# Altium schematic sheet COMMUNICATIONS.SchDoc
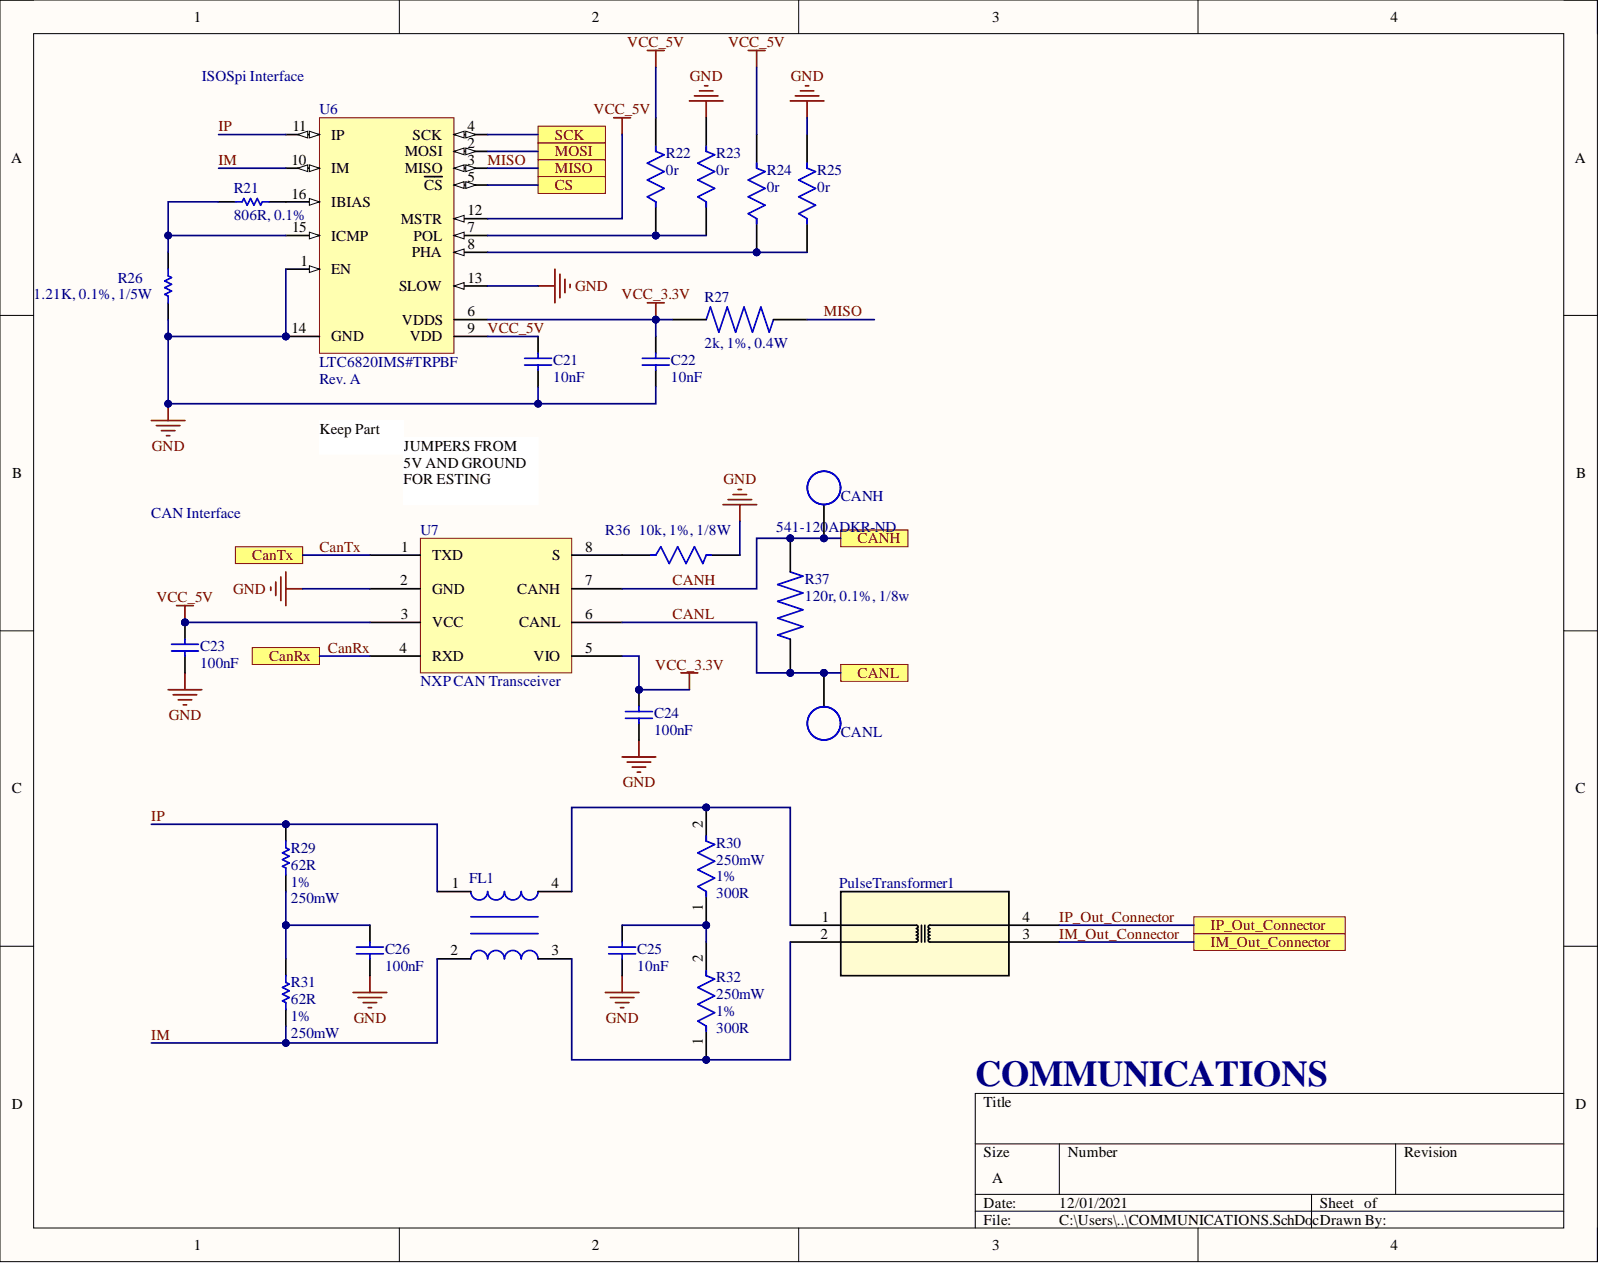
[diagram p0: COMMUNICATIONS.SchDoc]

## Parts
C21  10nF  [CAPC2013X70X45LL15T25]
C22  10nF  [CAPC2013X70X45LL15T25]
C23  100nF  [CAPC2013X145X45NL30T25]
C24  100nF  [CAPC2013X145X45NL30T25]
C25  10nF  [CAPC2013X70X45LL15T25]
C26  100nF  [CAPC2013X145X45NL30T25]
CANH  5015  [5015]  (value not drawn)
CANL  5015  [5015]  (value not drawn)
FL1  ACT45B-220-2P-TL003  [FIL_ACT45B-220-2P-TL003]  (value not drawn)
PulseTransformer1  PE-68386NLT  [PE-68386NL_PUL]  (value not drawn)
R21  806R, 0.1%  Tolerance: 0.1%  [RESC2013X50X30NL20T20]
R22  0r  [FP-CRCW0805-HPe3-IPC_A]
R23  0r  [FP-CRCW0805-HPe3-IPC_A]
R24  0r  [FP-CRCW0805-HPe3-IPC_A]
R25  0r  [FP-CRCW0805-HPe3-IPC_A]
R26  1.21K, 0.1%, 1/5W  [RESC2013X50X30NL20T20]
R27  2k, 1%, 0.4W  [RESC2012X50N]
R29  Max Power Dissipation: 250mW  Resistance: 62R  Resistance Tolerance: 1%  [RESC3216X70X50NL05T20]  (hidden comment: ERJ-8ENF62R0V)
R30  Max Power Dissipation: 250mW  Resistance: 300R  Tolerance: 1%  [FP-CRCW1206-e3-IPC_A]  (hidden comment: CRCW1206300RFKEA)
R31  Max Power Dissipation: 250mW  Resistance: 62R  Resistance Tolerance: 1%  [RESC3216X70X50NL05T20]  (hidden comment: ERJ-8ENF62R0V)
R32  Max Power Dissipation: 250mW  Resistance: 300R  Tolerance: 1%  [FP-CRCW1206-e3-IPC_A]  (hidden comment: CRCW1206300RFKEA)
R36  10k, 1%, 1/8W  [FP-CRCW0805-e3-IPC_A]
R37  120r, 0.1%, 1/8w  Supplier Part Number 1: 541-120ADKR-ND  [RESC2012X55N]
U6  LTC6820IMS#TRPBF  DatasheetVersion: Rev. A  [TSSOP50P490X110-16N]
U7  NXP CAN Transceiver  [NXP CAN Tranceiver]

## Ports
CANH, CANL, CanRx, CanTx, CS, IM_Out_Connector, IP_Out_Connector, MISO, MOSI, SCK

## Nets
GND: C21.1 C22.1 C23.1 C24.1 C25.1 C26.1 R23.2 R25.2 R26.1 R36.2 U6.1(EN) U6.13(SLOW) U6.14(GND) U7.2(GND)
VCC_3.3V: C22.2 C24.2 R27.2 U6.6(VDDS) U7.5(VIO)
CANH: CANH.1 R37.2 U7.7(CANH) ; port CANH
CANL: CANL.1 R37.1 U7.6(CANL) ; port CANL
CanRx: U7.4(RXD) ; port CanRx
CanTx: U7.1(TXD) ; port CanTx
CS: U6.5(CS) ; port CS
IM: FL1.2 R31.1 U6.10(IM)
IM_Out_Connector: PulseTransformer1.3 ; port IM_Out_Connector
IP: FL1.1 R29.2 U6.11(IP)
IP_Out_Connector: PulseTransformer1.4 ; port IP_Out_Connector
MISO: R27.1 U6.3(MISO) ; port MISO
MOSI: U6.2(MOSI) ; port MOSI
SCK: U6.4(SCK) ; port SCK
VCC_5V: C21.2 C23.2 R22.2 R24.2 U6.9(VDD) U6.12(MSTR) U7.3(VCC)
NetC25_2: C25.2 R30.1 R32.2
NetC26_2: C26.2 R29.1 R31.2
NetFL1_3: FL1.3 PulseTransformer1.2 R32.1
NetFL1_4: FL1.4 PulseTransformer1.1 R30.2
NetR21_1: R21.1 R26.2 U6.15(ICMP)
NetR21_2: R21.2 U6.16(IBIAS)
NetR22_1: R22.1 R23.1 U6.7(POL)
NetR24_1: R24.1 R25.1 U6.8(PHA)
NetR36_1: R36.1 U7.8(S)

## Library data of the parts
C21 C22 C25 = CMP-2007-00806-1: Manufacturer: Murata; Manufacturer Part Number 1: GCM216R72A103KA37D; Dielectric: X7R; Tolerance: 10%; Voltage: 100V; Capacitance: 10nF; Case Code (Imperial): 0805; Case Code (Metric): 2012; Case/Package: 0805; Category: Ceramic Capacitors; Component Kind: Standard; ComponentLink1Description: https://datasheet.ciiva.com/11620/product-11620072.pdf?src-supplier=Avnet; ComponentLink1URL: https://datasheet.ciiva.com/11620/product-11620072.pdf?src-supplier=Avnet; ComponentLink2Description: https://datasheet.ciiva.com/18183/getdatasheetpartid-223896-18183680.pdf?src-supplier=Verical; ComponentLink2URL: https://datasheet.ciiva.com/18183/getdatasheetpartid-223896-18183680.pdf?src-supplier=Verical; ComponentLink3Description: https://datasheet.ciiva.com/6270/s0717e-6270558.pdf?src-supplier=Digi-Key; ComponentLink3URL: https://datasheet.ciiva.com/6270/s0717e-6270558.pdf?src-supplier=Digi-Key; ComponentLink4Description: https://datasheet.ciiva.com/16214/s0717e-16214647.pdf?src-supplier=Future+Electronics; ComponentLink4URL: https://datasheet.ciiva.com/16214/s0717e-16214647.pdf?src-supplier=Future+Electronics; Construction: Flat; Depth: 1.25mm; Height: 0.6mm; Lead Free: Lead Free; Length: 2mm; Library Name: Altium Content Vault; Manufacturer 1: Murata; Max Operating Temperature: 125°C; Min Operating Temperature: -55°C; Mount: Surface Mount; Package Quantity: 1; Packaging: Tape and Reel; Part Number: GCM216R72A103KA37D; Pricing 1: 1=0.36000, 10=0.24900, 50=0.16640, 100=0.14140, 500=0.09980, 1000=0.08732 (USD); Radiation Hardening: No; Ratings: AEC-Q200; RoHS: Yes; RoHS Compliant: Yes; Series: GCM; Stock 1: 2316; Supplier 1: Digi-Key; Supplier Part Number 1: 490-6060-1-ND; Termination: SMD/SMT; Thickness: 0.028inch; Voltage Rating: 100V; Voltage Rating (DC): 100V; Width: 0.049inch
C23 C24 C26 = CMP-2007-03212-1: Manufacturer: Murata; Manufacturer Part Number 1: GCJ21BR71H104KA01L; Dielectric: X7R; Tolerance: 10%; Voltage Rating: 50V; Capacitance: 100nF; Case Code (Imperial): 0805; Case Code (Metric): 2012; Case/Package: 0805; Category: Ceramic Capacitors; Component Kind: Standard; ComponentLink1Description: https://datasheet.ciiva.com/17453/product-17453782.pdf?src-supplier=Avnet+Europe; ComponentLink1URL: https://datasheet.ciiva.com/17453/product-17453782.pdf?src-supplier=Avnet+Europe; ComponentLink2Description: https://datasheet.ciiva.com/18183/getdatasheetpartid-663717-18183469.pdf?src-supplier=Verical; ComponentLink2URL: https://datasheet.ciiva.com/18183/getdatasheetpartid-663717-18183469.pdf?src-supplier=Verical; ComponentLink3Description: https://datasheet.ciiva.com/136/s0717e-136749.pdf?src-supplier=Digi-Key; ComponentLink3URL: https://datasheet.ciiva.com/136/s0717e-136749.pdf?src-supplier=Digi-Key; ComponentLink4Description: https://datasheet.ciiva.com/136/s0717e-136750.pdf?src-supplier=Digi-Key; ComponentLink4URL: https://datasheet.ciiva.com/136/s0717e-136750.pdf?src-supplier=Digi-Key; ComponentLink5Description: https://datasheet.ciiva.com/136/s0717e-136751.pdf?src-supplier=Digi-Key; ComponentLink5URL: https://datasheet.ciiva.com/136/s0717e-136751.pdf?src-supplier=Digi-Key; Construction: Flat; Depth: 1.25mm; Features: Soft Termination; Height: 1.25mm; Lead Free: Lead Free; Length: 2mm; Library Name: Altium Content Vault; Manufacturer 1: Murata; Max Operating Temperature: 125°C; Min Operating Temperature: -55°C; Mount: Surface Mount; Package Quantity: 3000; Packaging: Tape and Reel; Part Number: GCJ21BR71H104KA01L; Pricing 1: 1=0.48000, 10=0.34200, 50=0.25880, 100=0.22540, 500=0.16698, 1000=0.14193 (USD); Radiation Hardening: No; Ratings: AEC-Q200; RoHS: Yes; RoHS Compliant: Yes; Series: GCJ; Stock 1: 105376; Supplier 1: Digi-Key; Supplier Part Number 1: 490-5803-1-ND; Termination: SMD/SMT; Thickness: 0.057inch; Voltage Rating (DC): 50V; Width: 0.049inch
CANH CANL = 5015: SMD Test Point; Manufacturer: Keystone Electronics; TargetLibrary: RITRacing-Connectors; Component Kind: Standard; ComponentLink1Description: Datasheet; ComponentLink1URL: http://www.mouser.com/ds/2/215/005-5009-743680.pdf; Library Name: Connectors.IntLib; PartNumber: 5015; Published: 22Oct2016; Status: Active; Publisher: T.Tallo
FL1 = ACT45B-220-2P-TL003: 100ÂµH @ 100kHz 2 Line Common Mode Choke Surface Mount 5.8 kOhms @ 10MHz 150mA DCR 2Ohm; Availability: Unavailable; Component Kind: Standard; MP: ACT45B-220-2P-TL003; Package: None; Price: None; Supplier 1: Digi-Key; Supplier 2: Digi-Key; Supplier 3: Digi-Key; Supplier 4: Mouser; Supplier Part Number 1: 445-3957-1-ND; Supplier Part Number 2: 445-3957-6-ND; Supplier Part Number 3: 445-3957-2-ND; Supplier Part Number 4: 810-ACT45B2202PTL003; Library Name: BMS_MasterboardUpdated_1.SCHLIB
PulseTransformer1 = PE-68386NLT: No Description Available; Built_By: EMA_Cory; SourceLibrary: Pulse_Electronics_2019-12-18; Vendor: Pulse Electronics Corporation; Component Kind: Standard; Manufacturer_Part_Number: PE-68386NLT; RefDes: RefDes; Supplier 1: Digi-Key; Supplier 2: Digi-Key; Supplier 3: Digi-Key; Supplier 4: Mouser; Supplier Part Number 1: 553-1776-1-ND; Supplier Part Number 2: 553-1776-6-ND; Supplier Part Number 3: 553-1776-2-ND; Supplier Part Number 4: 673-PE-68386NLT; Type: DEV; Copyright: Copyright (C) 2018 Accelerated Designs. All rights reserved; Library Name: BMS_MasterboardUpdated.SCHLIB
R21 = CMP-2001-04126-1: Manufacturer: Vishay Dale; Manufacturer Part Number 1: TNPW0805806RBEEN; Part Number: CRCW0805806RFKEA; Max Power Dissipation: 125mW; Temperature Coefficient: 25ppm/°C; Voltage Rating: 150V; Voltage Rating (DC): 100V; Case Code (Imperial): 0805; Case Code (Metric): 2012; Case/Package: 0805; Category: Chip SMD Resistors; Component Kind: Standard; ComponentLink1Description: https://datasheet.ciiva.com/2612/tnpw-e3-2612881.pdf?src-supplier=Digi-Key; ComponentLink1URL: https://datasheet.ciiva.com/2612/tnpw-e3-2612881.pdf?src-supplier=Digi-Key; ComponentLink2Description: https://datasheet.ciiva.com/8919/tnpw-e3-8919757.pdf?src-supplier=Avnet; ComponentLink2URL: https://datasheet.ciiva.com/8919/tnpw-e3-8919757.pdf?src-supplier=Avnet; ComponentLink3Description: https://datasheet.ciiva.com/48524/tnpw-e3-48524410.pdf?src-supplier=Avnet; ComponentLink3URL: https://datasheet.ciiva.com/48524/tnpw-e3-48524410.pdf?src-supplier=Avnet; Contact Plating: Tin; Features: Moisture Resistant,Automotive AEC-Q200,Anti-Sulfur; Height: 0.022inch; Length: 0.079inch; Library Name: Altium Content Vault; Manufacturer 1: Vishay Dale; Manufacturer 2: Vishay Dale; Manufacturer Part Number 2: TNPW0805806RBEEN; Max Operating Temperature: 125°C; Min Operating Temperature: -55°C; Number of Terminations: 2; Package Quantity: 1000; Packaging: Tape and Reel; Power Rating: 125mW; Pricing 1: 1000=0.31280 (USD); Pricing 2: 1=0.92000, 10=0.82300, 50=0.82300, 100=0.62200, 500=0.52900, 1000=0.29900, 10000=0.26400 (USD); Radiation Hardening: No; Resistance: 806R; Resistor Type: Thin Film; RoHS: Yes; RoHS Compliant: Yes; Series: TNPW; Stock 2: 696; Supplier 1: Digi-Key; Supplier 2: Mouser; Supplier Part Number 1: 541-TNPW0805806RBEENTR-ND; Supplier Part Number 2: 71-TNPW0805806RBEEN; Termination: SMD/SMT; Width: 0.049inch
R22-R25 = CMP-2001-04185-2: RES Thick Film, 0Ω, 0.5W, 0805; Manufacturer 1: Vishay Dale; Manufacturer Part Number 1: CRCW08050000Z0EAHP; Max Power Dissipation: 500mW; Power Rating: 330mW; Resistance: 0mR; Temperature Coefficient: 0ppm/°C; Tolerance: 5%; Voltage Rating: 150V; Case Code (Imperial): 0805; Case Code (Metric): 2012; Case/Package: 0805; Category: Chip SMD Resistors; Component Kind: Standard; ComponentLink1Description: https://datasheet.ciiva.com/2474/crcwhpe3-2474632.pdf?src-supplier=Digi-Key; ComponentLink1URL: https://datasheet.ciiva.com/2474/crcwhpe3-2474632.pdf?src-supplier=Digi-Key; ComponentLink2Description: https://datasheet.ciiva.com/2474/crcwhpe3-2474633.pdf?src-supplier=Digi-Key; ComponentLink2URL: https://datasheet.ciiva.com/2474/crcwhpe3-2474633.pdf?src-supplier=Digi-Key; ComponentLink3Description: https://datasheet.ciiva.com/2474/crcwhpe3-2474634.pdf?src-supplier=Digi-Key; ComponentLink3URL: https://datasheet.ciiva.com/2474/crcwhpe3-2474634.pdf?src-supplier=Digi-Key; ComponentLink4Description: https://datasheet.ciiva.com/9109/crcwhpe3-9109934.pdf?src-supplier=Avnet; ComponentLink4URL: https://datasheet.ciiva.com/9109/crcwhpe3-9109934.pdf?src-supplier=Avnet; ComponentLink5Description: https://datasheet.ciiva.com/5549/crcwhpe3-46056-5549241.pdf?src-supplier=Mouser; ComponentLink5URL: https://datasheet.ciiva.com/5549/crcwhpe3-46056-5549241.pdf?src-supplier=Mouser; ComponentLink6Description: https://datasheet.ciiva.com/16883/crcwhpe3-16883643.pdf?src-supplier=Avnet+Europe; ComponentLink6URL: https://datasheet.ciiva.com/16883/crcwhpe3-16883643.pdf?src-supplier=Avnet+Europe; ComponentLink7Description: https://datasheet.ciiva.com/16498/crcwhpe3-16498294.pdf?src-supplier=Future+Electronics; ComponentLink7URL: https://datasheet.ciiva.com/16498/crcwhpe3-16498294.pdf?src-supplier=Future+Electronics; ComponentLink8Description: https://datasheet.ciiva.com/28621/1750852-28621406.pdf?src-supplier=Element14; ComponentLink8URL: https://datasheet.ciiva.com/28621/1750852-28621406.pdf?src-supplier=Element14; ComponentLink9Description: https://datasheet.ciiva.com/7859/crcwhpe3-7859727.pdf?src-supplier=Element14; ComponentLink9URL: https://datasheet.ciiva.com/7859/crcwhpe3-7859727.pdf?src-supplier=Element14; Composition: Thick Film; Construction: Rectangular; Contact Plating: Tin; Depth: 1.25mm; Features: Automotive AEC-Q200; Height: 0.024inch; Lead Free: Lead Free; Length: 2mm; Library Name: Altium Content Vault; Max Operating Temperature: 155°C; Military Standard: Not; Min Operating Temperature: -55°C; Mount: Surface Mount; Number of Pins: 2; Number of Terminations: 2; Package Quantity: 5000; Packaging: Tape and Reel; Pricing 1: 1=0.21000, 10=0.17600, 25=0.12800, 50=0.09280, 100=0.06880, 250=0.05280, 500=0.04160, 1000=0.02880 (USD); Radiation Hardening: No; REACH SVHC: No SVHC; Resistor Type: Thick Film; RoHS: Yes; RoHS Compliant: Yes; Series: CRCW-HP; Size Code: 0805; Stock 1: 60898; Supplier 1: Digi-Key; Supplier Part Number 1: 541-0.0TBCT-ND; Technology: THICK FILM; Termination: Solder; Voltage Rating (DC): 150V; Weight: 0.000194oz; Width: 0.049inch
R26 = CMP-2001-04126-1: Manufacturer: Vishay Dale; Manufacturer Part Number 1: TNPW0805806RBEEN; Part Number: CRCW0805806RFKEA; Max Power Dissipation: 125mW; Resistance: 806R; Temperature Coefficient: 25ppm/°C; Voltage Rating: 150V; Voltage Rating (DC): 100V; Case Code (Imperial): 0805; Case Code (Metric): 2012; Case/Package: 0805; Category: Chip SMD Resistors; Component Kind: Standard; ComponentLink1Description: https://datasheet.ciiva.com/2612/tnpw-e3-2612881.pdf?src-supplier=Digi-Key; ComponentLink1URL: https://datasheet.ciiva.com/2612/tnpw-e3-2612881.pdf?src-supplier=Digi-Key; ComponentLink2Description: https://datasheet.ciiva.com/8919/tnpw-e3-8919757.pdf?src-supplier=Avnet; ComponentLink2URL: https://datasheet.ciiva.com/8919/tnpw-e3-8919757.pdf?src-supplier=Avnet; ComponentLink3Description: https://datasheet.ciiva.com/48524/tnpw-e3-48524410.pdf?src-supplier=Avnet; ComponentLink3URL: https://datasheet.ciiva.com/48524/tnpw-e3-48524410.pdf?src-supplier=Avnet; ComponentLink4Description: https://datasheet.ciiva.com/9105/tnpw-e3-9105739.pdf?src-supplier=Avnet; ComponentLink4URL: https://datasheet.ciiva.com/9105/tnpw-e3-9105739.pdf?src-supplier=Avnet; ComponentLink5Description: https://datasheet.ciiva.com/2608/tnpw-e3-2608321.pdf?src-supplier=Digi-Key; ComponentLink5URL: https://datasheet.ciiva.com/2608/tnpw-e3-2608321.pdf?src-supplier=Digi-Key; ComponentLink6Description: https://datasheet.ciiva.com/41828/tnpw-e3-41828137.pdf?src-supplier=Digi-Key; ComponentLink6URL: https://datasheet.ciiva.com/41828/tnpw-e3-41828137.pdf?src-supplier=Digi-Key; Contact Plating: Tin; Features: Moisture Resistant,Automotive AEC-Q200,Anti-Sulfur; Height: 0.022inch; Length: 0.079inch; Library Name: Altium Content Vault; Manufacturer 1: Vishay Dale; Manufacturer 2: Vishay Dale; Manufacturer 3: Vishay Dale; Manufacturer Part Number 2: TNPW0805806RBEEN; Manufacturer Part Number 3: TNPW08051K21BEEA; Max Operating Temperature: 125°C; Min Operating Temperature: -55°C; Number of Terminations: 2; Package Quantity: 1000; Packaging: Tape and Reel; Power Rating: 125mW; Pricing 1: 1000=0.31280 (USD); Pricing 2: 1=0.92000, 10=0.82300, 50=0.82300, 100=0.62200, 500=0.52900, 1000=0.29900, 10000=0.26400 (USD); Pricing 3: 1=0.74000, 10=0.54800, 100=0.31930, 1000=0.17905, 2500=0.17544 (USD); Radiation Hardening: No; REACH SVHC: Unknown; Resistance Tolerance: 0.1%; Resistor Type: Thin Film; RoHS: Yes; RoHS Compliant: Yes; Series: TNPW; Stock 2: 696; Stock 3: 4750; Supplier 1: Digi-Key; Supplier 2: Mouser; Supplier 3: Digi-Key; Supplier Part Number 1: 541-TNPW0805806RBEENTR-ND; Supplier Part Number 2: 71-TNPW0805806RBEEN; Supplier Part Number 3: 541-2057-1-ND; Termination: SMD/SMT; Tolerance: 0.1%; Width: 0.049inch
R27 = RCS08052K00FKEA: Res Thick Film 0805 2K Ohm 1% 0.4W ±100ppm/C Pad SMD Automotive T/R; Manufacturer 1: Vishay Dale; Max Power Dissipation: 400mW; Resistance: 2kR; Temperature Coefficient: 100ppm/°C; Availability: Unavailable; Case Code (Imperial): 0805; Case Code (Metric): 2012; Case/Package: 0805; Category: Chip SMD Resistors; Component Kind: Standard; ComponentLink1Description: http://www.vishay.com/docs/20065/rcse3.pdf?src-supplier=Digi-Key; ComponentLink1URL: http://www.vishay.com/docs/20065/rcse3.pdf?src-supplier=Digi-Key; Features: Automotive AEC-Q200; Height: 0.02inch; Length: 0.079inch; Manufacturer Part Number 1: RCS08052K00FKEA; Max Operating Temperature: 155°C; Min Operating Temperature: -55°C; MP: RCS08052K00FKEA; Number of Terminations: 2; Package: None; Packaging: Tape and Reel; Price: None; Pricing 1: 1=0.26000, 10=0.21800, 100=0.08510, 1000=0.03560, 2500=0.03264 (USD); Resistor Type: Thick Film; RoHS: Yes; Stock 1: 4243; Supplier 1: Digi-Key; Supplier Part Number 1: 541-2840-1-ND; Tolerance: 1%; Width: 0.049inch; Library Name: BMS_MasterboardUpdated_1.SCHLIB
R29 R31 = CMP-2003-00451-1: Manufacturer: Panasonic; Temperature Coefficient: 100ppm/°C; Voltage Rating: 200V; Case Code (Imperial): 1206; Case Code (Metric): 3216; Case/Package: 1206; Category: Chip SMD Resistors; Component Kind: Standard; ComponentLink1Description: https://datasheet.ciiva.com/9188/aoa0000ce2-9188406.pdf?src-supplier=Avnet; ComponentLink1URL: https://datasheet.ciiva.com/9188/aoa0000ce2-9188406.pdf?src-supplier=Avnet; ComponentLink2Description: https://datasheet.ciiva.com/22762/1678782-22762886.pdf?src-supplier=Farnell; ComponentLink2URL: https://datasheet.ciiva.com/22762/1678782-22762886.pdf?src-supplier=Farnell; ComponentLink3Description: https://datasheet.ciiva.com/46440/1678782-46440394.pdf?src-supplier=Element14; ComponentLink3URL: https://datasheet.ciiva.com/46440/1678782-46440394.pdf?src-supplier=Element14; Construction: Rectangular; Contact Plating: Tin; Depth: 1.6mm; Features: Automotive AEC-Q200; Height: 0.028inch; Lead Free: Lead Free; Length: 3.2mm; Library Name: Altium Content Vault; Manufacturer 1: Panasonic; Manufacturer 2: Panasonic; Manufacturer 3: Panasonic; Manufacturer 4: Panasonic; Manufacturer Part Number 1: ERJ-8ENF62R0V; Manufacturer Part Number 2: ERJ-8ENF62R0V; Manufacturer Part Number 3: ERJ-8ENF62R0V; Manufacturer Part Number 4: ERJ-8ENF62R0V; Max Operating Temperature: 155°C; Military Standard: Not; Min Operating Temperature: -55°C; Mount: Surface Mount; Number of Terminations: 2; Package Quantity: 1; Packaging: Tape and Reel; Part Number: ERJ-8ENF62R0V; Power Rating: 250mW; Pricing 1: 1=0.10000, 10=0.09300, 100=0.03560, 1000=0.01569, 2500=0.01355 (USD); Pricing 2: 1=0.10000, 10=0.09300, 100=0.03560, 1000=0.01569, 2500=0.01355 (USD); Pricing 3: 1=0.00800, 25=0.00800, 50=0.00800, 100=0.00800, 250=0.00800, 500=0.00800, 1000=0.00800 (USD); Pricing 4: 1=0.10000, 10=0.09300, 50=0.09300, 100=0.03800, 250=0.03700, 500=0.03600, 1000=0.01600, 2500=0.01400, 5000=0.01300, 10000=0.01300 (USD); Radiation Hardening: No; REACH SVHC: Yes; Resistor Type: Thick Film; RoHS: Yes; RoHS Compliant: Yes; Series: ERJ; Stock 1: 91284; Stock 2: 91284; Stock 3: 46632; Stock 4: 31443; Supplier 1: Digi-Key; Supplier 2: Digi-Key; Supplier 3: Newark; Supplier 4: Mouser; Supplier Part Number 1: P62.0FCT-ND; Supplier Part Number 2: P62.0FDKR-ND; Supplier Part Number 3: 90W3970; Supplier Part Number 4: 667-ERJ-8ENF62R0V; Termination: SMD/SMT; Tolerance: 1%; Voltage Rating (DC): 200V; Width: 1.6mm
R30 R32 = CMP-1014-00449-3: RES Thick Film, 300Ω, 1%, 0.25W, 100ppm/°C, 1206; Voltage Rating: 200V; Case Code (Imperial): 1206; Case Code (Metric): 3216; Case/Package: 1206; Component Kind: Standard; Composition: Thick Film; Contact Plating: Tin; Depth: 1.6mm; Features: Automotive AEC-Q200; Height: 0.55mm; Lead Free: Lead Free; Length: 3.2mm; Library Name: Altium Content Vault; Max Operating Temperature: 155°C; Military Standard: Not; Min Operating Temperature: -55°C; Mount: Surface Mount; Number of Terminations: 2; Package Quantity: 10; Packaging: Tape and Reel; Power Rating: 250mW; Radiation Hardening: No; Supplier 1: Newark; Supplier Part Number 1: 27W4914; Temperature Coefficient: 100ppm/°C; Termination: SMD/SMT; Voltage Rating (DC): 200V; Weight: 0.000353oz; Width: 0.063inch; Working Voltage: 200V
R36 = CMP-2001-04908-2: RES Thick Film, 10kΩ, 1%, 0.125W, 100ppm/°C, 0805; Manufacturer 1: Vishay; Manufacturer Part Number 1: CRCW080510K0FKEA; Max Power Dissipation: 125mW; Resistance: 10kR; Voltage Rating: 150V; Case Code (Imperial): 0805; Case Code (Metric): 2012; Case/Package: 0805; Category: Chip SMD Resistors; Component Kind: Standard; ComponentLink1Description: https://datasheet.ciiva.com/11808/0900766b80d98b26-11808216.pdf?src-supplier=RSComponents; ComponentLink1URL: https://datasheet.ciiva.com/11808/0900766b80d98b26-11808216.pdf?src-supplier=RSComponents; ComponentLink2Description: https://datasheet.ciiva.com/8337/dcrcwe3-8337773.pdf?src-supplier=Avnet; ComponentLink2URL: https://datasheet.ciiva.com/8337/dcrcwe3-8337773.pdf?src-supplier=Avnet; ComponentLink3Description: https://datasheet.ciiva.com/8337/dcrcwe3-8337774.pdf?src-supplier=Avnet; ComponentLink3URL: https://datasheet.ciiva.com/8337/dcrcwe3-8337774.pdf?src-supplier=Avnet; ComponentLink4Description: https://datasheet.ciiva.com/5547/dcrcwe3-109170-5547559.pdf?src-supplier=Mouser; ComponentLink4URL: https://datasheet.ciiva.com/5547/dcrcwe3-109170-5547559.pdf?src-supplier=Mouser; ComponentLink5Description: https://datasheet.ciiva.com/46394/1720486-46394381.pdf?src-supplier=Element14; ComponentLink5URL: https://datasheet.ciiva.com/46394/1720486-46394381.pdf?src-supplier=Element14; ComponentLink6Description: https://datasheet.ciiva.com/16199/dcrcwe3-16199086.pdf?src-supplier=Future+Electronics; ComponentLink6URL: https://datasheet.ciiva.com/16199/dcrcwe3-16199086.pdf?src-supplier=Future+Electronics; ComponentLink7Description: https://datasheet.ciiva.com/16883/dcrcwe3-16883708.pdf?src-supplier=Avnet+Europe; ComponentLink7URL: https://datasheet.ciiva.com/16883/dcrcwe3-16883708.pdf?src-supplier=Avnet+Europe; ComponentLink8Description: https://datasheet.ciiva.com/16719/70201119-16719082.pdf?src-supplier=Allied+Electronics; ComponentLink8URL: https://datasheet.ciiva.com/16719/70201119-16719082.pdf?src-supplier=Allied+Electronics; ComponentLink9Description: https://datasheet.ciiva.com/16514/dcrcwe3-16514907.pdf?src-supplier=Avnet+Asia; ComponentLink9URL: https://datasheet.ciiva.com/16514/dcrcwe3-16514907.pdf?src-supplier=Avnet+Asia; ComponentLink10Description: https://datasheet.ciiva.com/16755/70201119-16755389.pdf?src-supplier=Allied+Electronics; ComponentLink10URL: https://datasheet.ciiva.com/16755/70201119-16755389.pdf?src-supplier=Allied+Electronics; Composition: Thick Film; Construction: Rectangular; Contact Plating: Tin; Depth: 1.25mm; Features: Automotive AEC-Q200; Height: 0.45mm; Lead Free: Lead Free; Length: 2mm; Library Name: Altium Content Vault; Manufacturer 2: Vishay; Manufacturer Part Number 2: CRCW080510K0FKEA; Max Operating Temperature: 155°C; Military Standard: Not; Min Operating Temperature: -55°C; Number of Terminations: 2; Package Quantity: 5000; Packaging: Tape and Reel; Power Rating: 125mW; Pricing 1: 1=0.01100, 5000=0.01100 (USD); Pricing 2: 1=0.10000, 10=0.06700, 100=0.02710, 1000=0.01219, 2500=0.01058 (USD); Radiation Hardening: No; REACH SVHC: Unknown; Resistance Tolerance: 1%; Resistor Type: Thick Film; RoHS: Yes; RoHS Compliant: Yes; Series: CRCW; Size Code: 0805; Stock 1: 1440000; Supplier 1: Newark; Supplier 2: Digi-Key; Supplier Part Number 1: 25AC7644; Supplier Part Number 2: 541-10.0KCCT-ND; Technology: THICK FILM; Temperature Coefficient: 100ppm/°C; Termination: SMD/SMT; Tolerance: 1%; Voltage Rating (DC): 150V; Weight: 0.000194oz; Width: 1.25mm
R37 = TNPW08051K21BEEA: Availability: Unavailable; Component Kind: Standard; MP: TNPW08051K21BEEA; Package: None; Price: None; Supplier 1: Digi-Key; Library Name: BMS_MasterboardUpdated_1.SCHLIB
U6 = CMP-0937-00011-1: IsoSPI Isolated Communication Interface, 2.7 to 5.5 V, -40 to 85 degC, 16-Pin MSOP (MS), Pb-Free, Tape and Reel; Manufacturer: Linear Technology; IO Supply Current: 1 uA Max.; IO Supply Voltage Range: 1.7 to 5.5 V; Receiver Input Resistance: 35 kOhm; Supply Current, IDLE State: 2 uA; VBIAS: 1.9 to 2.1 V; Component Kind: Standard; ComponentLink1Description: Manufacturer URL; ComponentLink1URL: http://www.linear.com/; ComponentLink2Description: Datasheet; ComponentLink2URL: http://cds.linear.com/docs/en/datasheet/6820fa.pdf; ComponentLink3Description: Package Specification; ComponentLink3URL: http://cds.linear.com/docs/en/packaging/05081669_A_MS16.pdf; Manufacturer Part Number: LTC6820IMS#TRPBF; Mounting Technology: Surface Mount; PackageDescription: 16-Pin Micro Small Outline Package, Body 4.039 x 3 mm, Pitch 0.5 mm; PackageReference: MS-16; PackageVersion: Rev. A; Packing: Tape and Reel; PartNumber: LTC6820IMS#TRPBF; Power Supply: 2.7 to 5.5 V; Supplier 1: Digi-Key; Supplier 2: Mouser; Supplier Part Number 1: LTC6820IMS#TRPBFCT-ND; Supplier Part Number 2: 584-LTC6820IMS#TRPBF; Temperature Range (degC): -40 to 85; Library Name: BMS_MasterboardUpdated.SCHLIB
U7 = NXP CAN Transceiver: IC CAN TRANSEIVER HS 8SOIC; Manufacturer 1: NXP Semiconductors; Manufacturer Part Number 1: TJF1051T/1J; Data Rate: 5Mbps; Max Supply Voltage: 5.5V; Min Supply Voltage: 4.5V; Number of Transceivers: 1; Receiver Hysteresis: 120mV; Simplex/Duplex: Half Duplex; Case/Package: SOIC; Category: Interface ICs; Component Kind: Standard; ComponentLink1Description: Datasheet; ComponentLink1URL: https://www.farnell.com/datasheets/2080616.pdf; ComponentLink2Description: Supplier Link; ComponentLink2URL: https://www.newark.com/nxp/tjf1051t-1j/can-transceiver-5mbps-soic-8/dp/61AC2368?gclid=CjwKCAiA1eKBBhBZEiwAX3gqlxQsUZSrTqhgUbpvxTd09IiKsEelRDLU8t4m6T0nWWFbevXOORPvhRoC6uIQAvD_BwE&mckv=sitHQX2q7_dc|pcrid|492622077320|plid||kword||match||slid||product|61AC2368|pgrid|108905520170|ptaid|pla-902764019279|&CMP=KNC-GUSA-GEN-Shopping-NewStructure-Manuf-Semiconductors-ICs; ComponentLink3Description: http://www.farnell.com/datasheets/2080616.pdf?src-supplier=Farnell; ComponentLink3URL: http://www.farnell.com/datasheets/2080616.pdf?src-supplier=Farnell; ComponentLink4Description: http://www.nxp.com/documents/data_sheet/TJF1051.pdf?src-supplier=Avnet; ComponentLink4URL: http://www.nxp.com/documents/data_sheet/TJF1051.pdf?src-supplier=Avnet; Max Operating Temperature: 125°C; Min Operating Temperature: -40°C; Mount: Surface Mount; Number of Pins: 8; Packaging: Tape and Reel; Pricing 1: 1=1.29000, 10=1.16000, 25=1.10000, 50=1.00000, 100=0.90400, 250=0.84500 (USD); RoHS: Yes; RoHS Compliant: Yes; Supplier 1: Newark; Supplier Part Number 1: 61AC2368; Library Name: BMS_MasterboardUpdated_1.SCHLIB
